# Altium schematic sheet Inverter_Hall IC_110V.SchDoc
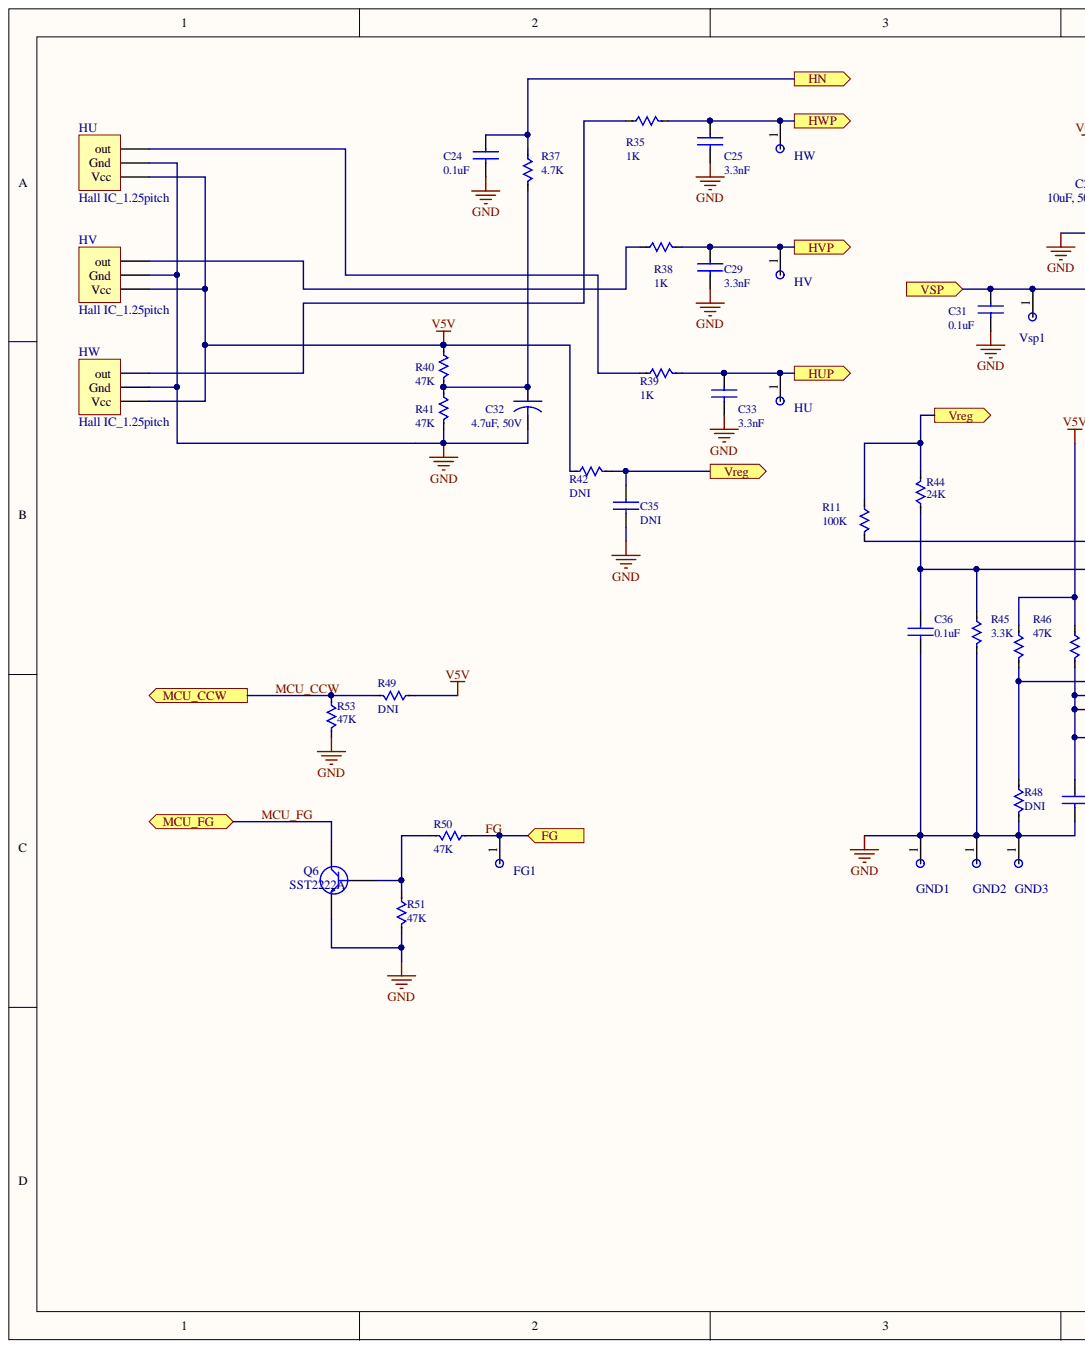
[diagram p0: Inverter_Hall IC_110V.SchDoc, left]
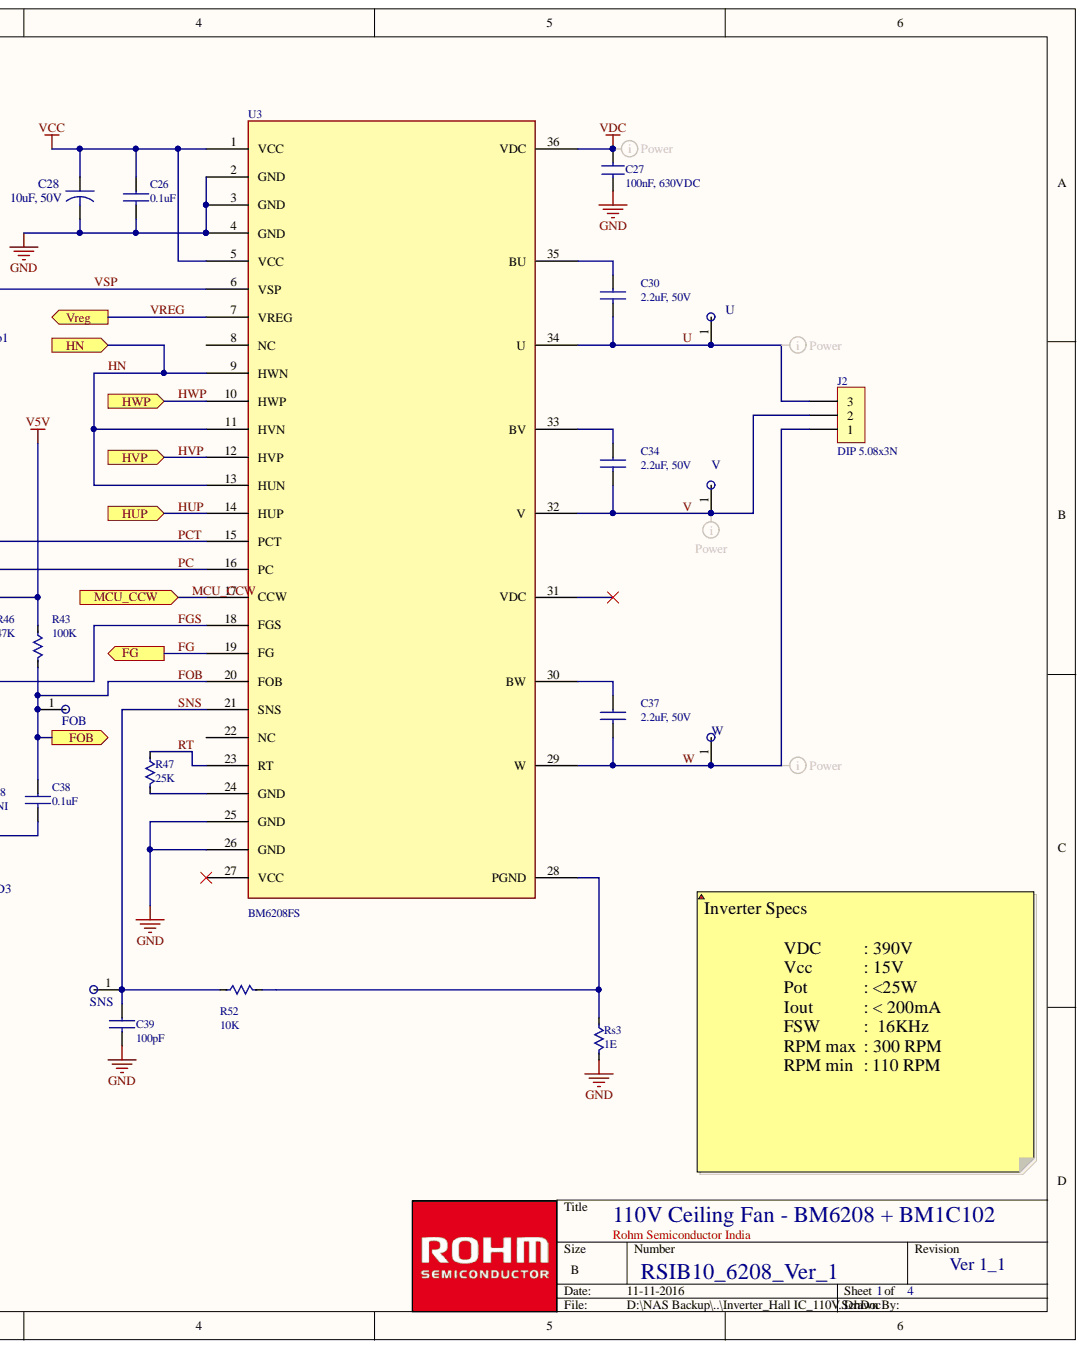
[diagram p1: Inverter_Hall IC_110V.SchDoc, right]

## Parts
C24  0.1uF  [C0805]
C25  3.3nF  [C0805]
C26  0.1uF  [C0805]
C27  100nF, 630VDC  [Cap - 15.24mm BOX]
C28  10uF, 50V  Voltage: 50V  [CAP_M_5/2]
C29  3.3nF  [C0805]
C30  2.2uF, 50V  [C0805]
C31  0.1uF  [C0805]
C32  4.7uF, 50V  Voltage: 50V  [CAP_M_5/2]
C33  3.3nF  [C0805]
C34  2.2uF, 50V  [C0805]
C35  DNI  [C0805]
C36  0.1uF  [C0805]
C37  2.2uF, 50V  [C0805]
C38  0.1uF  [C0805]
C39  100pF  [C0603]
FG1  TP  [TP_5002]  (value not drawn)
FOB  TP  [TP_5002]  (value not drawn)
GND1  TP  [TP_5002]  (value not drawn)
GND2  TP  [TP_5002]  (value not drawn)
GND3  TP  [TP_5002]  (value not drawn)
HU  Hall IC_1.25pitch  [Hall IC]
HU  TP  [TP_5002]  (value not drawn)
HV  Hall IC_1.25pitch  [Hall IC]
HV  TP  [TP_5002]  (value not drawn)
HW  Hall IC_1.25pitch  [Hall IC]
HW  TP  [TP_5002]  (value not drawn)
J2  DIP 5.08x3N  [CON_10mm pitch]
Q6  SST2222A  [SOT-23]
R11  100K  [R0805]
R35  1K  [R0805]
R37  4.7K  [R0805]
R38  1K  [R0805]
R39  1K  [R0805]
R40  47K  [R0805]
R41  47K  [R0805]
R42  DNI  [R0805]
R43  100K  [R0805]
R44  24K  [R0805]
R45  3.3K  [R0805]
R46  47K  [R0805]
R47  25K  [R0805]
R48  DNI  [R0805]
R49  DNI  [R0805]
R50  47K  [R0805]
R51  47K  [R0805]
R52  10K  [R0805]
R53  47K  [R0805]
Rs3  1E  [LR2512]
SNS  TP  [TP_5002]  (value not drawn)
U  TP  [TP_5002]  (value not drawn)
U3  BM6208FS  [BM620x - SOP80P1410X220-54N]
V  TP  [TP_5002]  (value not drawn)
Vsp1  TP  [TP_5002]  (value not drawn)
W  TP  [TP_5002]  (value not drawn)

## Ports
FG, FOB, HN, HUP, HVP, HWP, MCU_CCW, MCU_FG, VREG, VSP

## Nets
GND: C24.2 C25.2 C26.2 C27.2 C28.2 C29.2 C31.2 C32.2 C33.2 C35.2 C36.2 C38.2 C39.2 GND1.1 GND2.1 GND3.1 HU.2 HV.2 HW.2 Q6.2 R41.2 R45.2 R48.2 R51.2 R53.2 Rs3.2 U3.2(GND) U3.3(GND) U3.4(GND) U3.25(GND) U3.26(GND)
V5V: HU.1 HV.1 HW.1 R40.1 R42.1 R43.1 R46.1 R49.1
VCC: C26.1 C28.1 U3.1(VCC) U3.5(VCC)
VDC: C27.1 U3.36(VDC)
FG: FG1.1 R50.1 U3.19(FG) ; port FG
FGS: R46.2 R48.1 U3.18(FGS)
FOB: C38.1 FOB.1 R43.2 U3.20(FOB) ; port FOB
HN: C24.1 R37.1 U3.9(HWN) U3.11(HVN) U3.13(HUN) ; port HN
HUP: C33.1 HU.1 R39.2 U3.14(HUP) ; port HUP
HVP: C29.1 HV.1 R38.2 U3.12(HVP) ; port HVP
HWP: C25.1 HW.1 R35.2 U3.10(HWP) ; port HWP
MCU_CCW: R49.2 R53.1 U3.17(CCW) ; port MCU_CCW
MCU_FG: Q6.3 ; port MCU_FG
PC: C36.1 R44.1 R45.1 U3.16(PC)
PCT: R11.2 U3.15(PCT)
RT: R47.1 U3.23(RT)
SNS: C39.1 R52.1 SNS.1 U3.21(SNS)
U: C30.2 J2.3 U.1 U3.34(U)
V: C34.2 J2.2 U3.32(V) V.1
VREG: C35.1 R11.1 R42.2 R44.2 U3.7(VREG) ; port VREG
VSP: C31.1 U3.6(VSP) Vsp1.1 ; port VSP
W: C37.2 J2.1 U3.29(W) W.1
NetC30_1: C30.1 U3.35(BU)
NetC32_1: C32.1 R37.2 R40.2 R41.1
NetC34_1: C34.1 U3.33(BV)
NetC37_1: C37.1 U3.30(BW)
NetHU_3: HU.3 R39.1
NetHV_3: HV.3 R38.1
NetHW_3: HW.3 R35.1
NetQ6_1: Q6.1 R50.2 R51.1
NetR47_2: R47.2 U3.24(GND)
NetR52_2: R52.2 Rs3.1 U3.28(PGND)

Not connected: U3 8(NC) 22(NC) 27(VCC) 31(VDC)

## Library data of the parts
C24 = CAP NP: Section: Inverter; Value: 0.004615; Component Kind: Standard; Supplier 1: Wurth*; Supplier Part Number 1: 885012207072; Library Name: Altium_Ara_Sch_libraries_R1.SchLib
C25 C29 C33 = CAP NP: 2.2nF, 25V, X7R capacitor; Section: Inverter; Value: 0.0145; Component Kind: Standard; Supplier 1: Wurth; Supplier Part Number 1: 885012007043; Voltage: 25V; Library Name: Altium_Ara_Sch_libraries_R1.SchLib
C26 C31 C36 C38 = CAP NP: Section: Inverter; Type: SMD_Cap; Value: 0.004615; Component Kind: Standard; Supplier 1: *Wurth; Supplier Part Number 1: 885 012 207 072; Library Name: Altium_Ara_Sch_libraries_R1.SchLib
C27 = Cap - Polyster: Capcitor Polyster; Section: Inverter; Type: TH_Cap; Value: 0.15; Component Kind: Standard; Supplier 1: Kemet; Supplier Part Number 1: R60PI3100AAL0K; Voltage: 630VDC; Library Name: Altium_Ara_Sch_libraries_R1.SchLib
C28 = Cap TH 5/2: CAP ALUM 10UF 20% 50V RADIAL; Section: Aux PS; Type: Electrolytic; Value: 0.023; Component Kind: Standard; Supplier 1: *Samwha; Supplier Part Number 1: SD1H106M05011PC; Library Name: Altium_Ara_Sch_libraries_R1.SchLib
C30 C34 C37 = CAP NP: Section: Inverter; Type: SMD_Cap; Value: 0.012789; Component Kind: Standard; Supplier 1: *Murata; Supplier Part Number 1: GRM219R61H225KE15J; Voltage: 50V; Library Name: Altium_Ara_Sch_libraries_R1.SchLib
C32 = Cap TH 5/2: Electrolytic Capacitor , 5mm dia, 2mm Pitch; Section: Inverter; Value: .02; Component Kind: Standard; Supplier 1: Panasonic; Supplier Part Number 1: EEU-EB1H4R7SB-ND; Library Name: Altium_Ara_Sch_libraries_R1.SchLib
C35 = CAP NP: Section: Inverter; Value: 0; Component Kind: Standard; Supplier 1: DNI; Supplier Part Number 1: DNI; Library Name: Altium_Ara_Sch_libraries_R1.SchLib
C39 = CAP NP: Section: Inverter; Type: SMD_Cap; Value: 0.000672; Voltage: 25V; Component Kind: Standard; Supplier 1: *Murata; Supplier Part Number 1: GRM033R71C101JA01D; Library Name: Altium_Ara_Sch_libraries_R1.SchLib
FG1 FOB GND1-GND3 HU HV HW SNS U V Vsp1 W = TP: Section: Inverter; Value: 0; Component Kind: Standard; Supplier 1: DNI; Supplier Part Number 1: DNI; Library Name: Altium_Ara_Sch_libraries_R1.SchLib
  FG1 FOB GND1-GND3 SNS U V Vsp1 W: Type: DNI
HU HV HW = Hall: Connector; Section: Inverter; Value: .015; Component Kind: Standard; Supplier 1: Asahi Kasei; Supplier Part Number 1: EW732; Library Name: Altium_Ara_Sch_libraries_R1.SchLib
J2 = CON3: Connector; Section: Inverter; Value: 0.15; Component Kind: Standard; Supplier 1: *Molex; Supplier Part Number 1: 10321031; Type: Connector; Library Name: Altium_Ara_Sch_libraries_R1.SchLib
Q6 = NPN: NPN Transistor; Section: Inverter; Type: Trans/FET; Value: 0.00940; Component Kind: Standard; Supplier 1: *Rohm; Supplier Part Number 1: SST2222AMGT116; Library Name: Altium_Ara_Sch_libraries_R1.SchLib
R11 R43-R47 R49-R53 = R0805: Resistor; Section: Inverter; Value: 0.00126; Component Kind: Standard; Type: SMD_resistor; Library Name: Altium_Ara_Sch_libraries_R1.SchLib
  R11 R43: Supplier 1: *Rohm; Supplier Part Number 1: MCR10EZPF1003
  R44: Supplier 1: Rohm; Supplier Part Number 1: MCR10EZPF1502
  R45: Supplier 1: *Rohm; Supplier Part Number 1: MCR10EZPF5102
  R46 R50 R51 R53: Supplier 1: *Rohm; Supplier Part Number 1: MCR10EZPF4702
  R47: Supplier 1: Yageo; Supplier Part Number 1: RT1206BRD0725KL
  R49: Supplier 1: Rohm; Supplier Part Number 1: DNI
  R52: Supplier 1: *Rohm; Supplier Part Number 1: MCR10EZPF1002
R35 R38 R39 = R0805: 150K, 1%, 0.125W,0805 resitor; Section: Inverter; Value: 0.0017; Component Kind: Standard; Supplier 1: Rohm*; Supplier Part Number 1: MCR10EZPF1001; Library Name: Altium_Ara_Sch_libraries_R1.SchLib
R37 = R0805: 4.7K, 1%, 0.125W, 0805 Resistor; Section: Inverter; Value: 0.0017; Component Kind: Standard; Supplier 1: Rohm*; Supplier Part Number 1: MCR10EZPF4701; Library Name: Altium_Ara_Sch_libraries_R1.SchLib
R40 R41 = R0805: 4.7K, 1%, 0.125W, 0805 Resistor; Section: Inverter; Value: 0.00126; Component Kind: Standard; Supplier 1: Rohm*; Supplier Part Number 1: MCR10EZPF4702; Library Name: Altium_Ara_Sch_libraries_R1.SchLib
R42 = R0805: 1K, 1%, 0.125W, 0805 Resistor; Section: Inverter; Value: 0; Component Kind: Standard; Supplier 1: DNI; Supplier Part Number 1: DNI; Library Name: Altium_Ara_Sch_libraries_R1.SchLib
R48 = R0805: Resistor; Section: Inverter; Value: 0; Component Kind: Standard; Supplier 1: *Rohm; Supplier Part Number 1: DNI; Type: DNI; Library Name: Altium_Ara_Sch_libraries_R1.SchLib
Rs3 = R1206: Resistor; Section: Inverter; Value: 0.04100; Component Kind: Standard; Supplier 1: *Rohm; Supplier Part Number 1: LTR18EZPF1R50; Type: SMD_resistor; Library Name: Altium_Ara_Sch_libraries_R1.SchLib
U3 = BM6207FS_3: Section: Inverter; Value: 1.8; Component Kind: Standard; Supplier 1: *Rohm; Supplier Part Number 1: BM6208FS-E2; Type: IC; Library Name: Altium_Ara_Sch_libraries_R1.SchLib
